# Altium schematic sheet Digital_Output.SchDoc
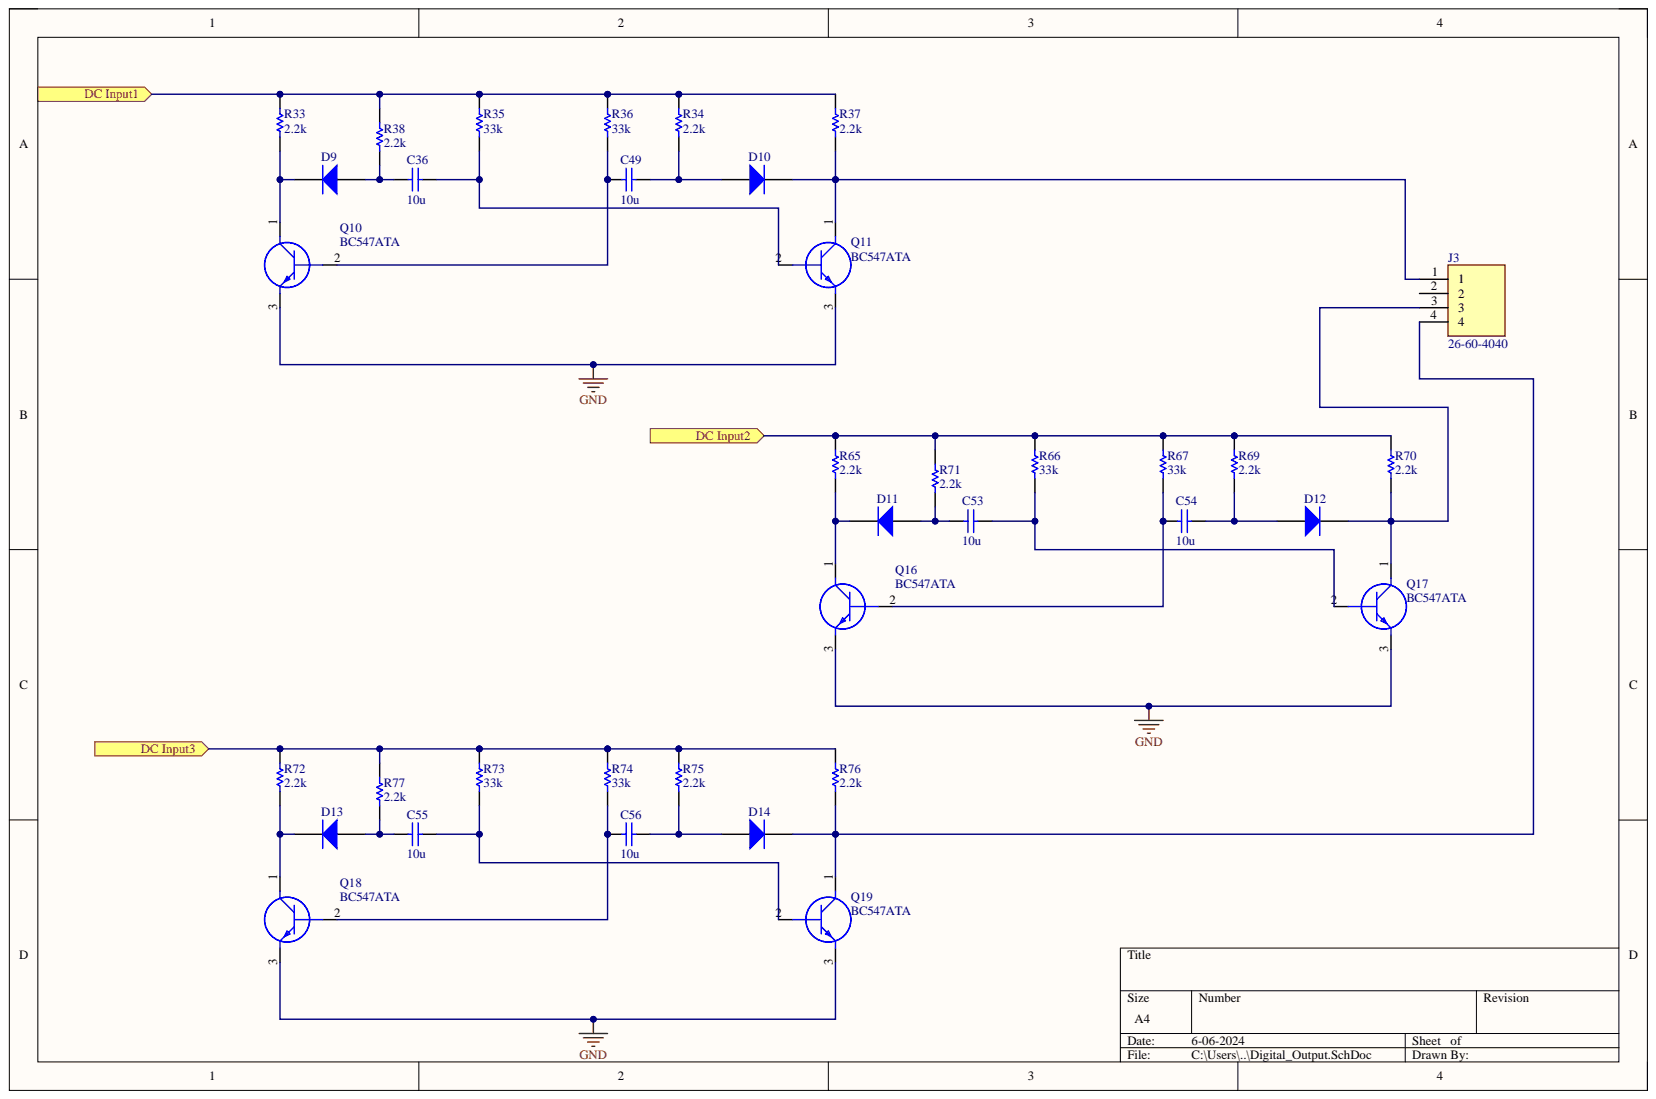
[diagram p0: Digital_Output.SchDoc]

## Parts
C36  value 10u  (hidden comment: Capacitor)
C49  value 10u  (hidden comment: Capacitor)
C53  value 10u  (hidden comment: Capacitor)
C54  value 10u  (hidden comment: Capacitor)
C55  value 10u  (hidden comment: Capacitor)
C56  value 10u  (hidden comment: Capacitor)
D9  Diode  (value not drawn)
D10  Diode  (value not drawn)
D11  Diode  (value not drawn)
D12  Diode  (value not drawn)
D13  Diode  (value not drawn)
D14  Diode  (value not drawn)
J3  26-60-4040  [SHDR4W155P0X396_1X4_1570X1001X1536P]
Q10  BC547ATA  [TO-92_(TO-226)]
Q11  BC547ATA  [TO-92_(TO-226)]
Q16  BC547ATA  [TO-92_(TO-226)]
Q17  BC547ATA  [TO-92_(TO-226)]
Q18  BC547ATA  [TO-92_(TO-226)]
Q19  BC547ATA  [TO-92_(TO-226)]
R33  value 2.2k  (hidden comment: Resistor)
R34  value 2.2k  (hidden comment: Resistor)
R35  value 33k  (hidden comment: Resistor)
R36  value 33k  (hidden comment: Resistor)
R37  value 2.2k  (hidden comment: Resistor)
R38  value 2.2k  (hidden comment: Resistor)
R65  value 2.2k  (hidden comment: Resistor)
R66  value 33k  (hidden comment: Resistor)
R67  value 33k  (hidden comment: Resistor)
R69  value 2.2k  (hidden comment: Resistor)
R70  value 2.2k  (hidden comment: Resistor)
R71  value 2.2k  (hidden comment: Resistor)
R72  value 2.2k  (hidden comment: Resistor)
R73  value 33k  (hidden comment: Resistor)
R74  value 33k  (hidden comment: Resistor)
R75  value 2.2k  (hidden comment: Resistor)
R76  value 2.2k  (hidden comment: Resistor)
R77  value 2.2k  (hidden comment: Resistor)

## Ports
DC Input1, DC Input2, DC Input3

## Nets
GND: Q10.3 Q11.3 Q16.3 Q17.3 Q18.3 Q19.3
DC Input1: R33.2 R34.2 R35.2 R36.2 R37.2 R38.2 ; port DC Input1
DC Input2: R65.2 R66.2 R67.2 R69.2 R70.2 R71.2 ; port DC Input2
DC Input3: R72.2 R73.2 R74.2 R75.2 R76.2 R77.2 ; port DC Input3
NetC36_1: C36.1 D9.1 R38.1
NetC36_2: C36.2 Q11.2 R35.1
NetC49_1: C49.1 Q10.2 R36.1
NetC49_2: C49.2 D10.1 R34.1
NetC53_1: C53.1 D11.1 R71.1
NetC53_2: C53.2 Q17.2 R66.1
NetC54_1: C54.1 Q16.2 R67.1
NetC54_2: C54.2 D12.1 R69.1
NetC55_1: C55.1 D13.1 R77.1
NetC55_2: C55.2 Q19.2 R73.1
NetC56_1: C56.1 Q18.2 R74.1
NetC56_2: C56.2 D14.1 R75.1
NetD9_2: D9.2 Q10.1 R33.1
NetD10_2: D10.2 J3.1 Q11.1 R37.1
NetD11_2: D11.2 Q16.1 R65.1
NetD12_2: D12.2 J3.3 Q17.1 R70.1
NetD13_2: D13.2 Q18.1 R72.1
NetD14_2: D14.2 J3.4 Q19.1 R76.1

Not connected: J3 2

## Library data of the parts
C36 C49 C53-C56 = Capacitor: SimulationGenericComponent: True; Component Kind: Standard; Description: Capacitor; Library Name: Simulation Generic Components.IntLib; Simulation: C_Capacitor_Default
D9-D14 = Diode: AF: 1; BV: 0; BV_MAX: 1e99; EG: 1.11; FC: 0.5; IBV: 0.001; IS: 1e-14; MJSW: 0.33; NTUN: 30; SimulationGenericComponent: True; TNOM: 27; XTI: 3; CJO: 0; CJP: 0; Component Kind: Standard; CTA: 0; CTP: 0; Description: Diode; FCS: 0.5; FV_MAX: 1e99; IKF: 0; IKR: 0; ISR: 1e-14; JSW: 0; JTUN: 0; JTUNSW: 0; KEG: 1; KF: 0; LEVEL: 1; Library Name: Simulation Generic Components.IntLib; N: 1; NBV: 1; NR: 1; NS: 1; PHP: 1; RS: 0; Simulation: diode; TCV: 0; TLEV: 0; TLEVC: 0; TM1: 0; TM2: 0; TPB: 0; TPHP: 0; TRS: 0; TRS2: 0; TT: 0; TTT1: 0; TTT2: 0; VJ: 1; XTITUN: 3
J3 = 26-60-4040: Connector; Manufacturer_Name: Molex; Component Kind: Standard; Datasheet Link: http://www.molex.com/pdm_docs/sd/026604040_sd.pdf; Height: 15.36mm; Manufacturer_Part_Number: 26-60-4040; Mouser Part Number: 538-26-60-4040; Mouser Price/Stock: https://www.mouser.co.uk/ProductDetail/Molex/26-60-4040?qs=tRPrwvvr%2FuiQpDQcO3P3Lw%3D%3D
Q10 Q11 Q16-Q19 = BC547ATA: Transistor BJT NPN; Manufacturer_Name: onsemi; Arrow Part Number: BC547ATA; Arrow Price/Stock: https://www.arrow.com/en/products/bc547ata/on-semiconductor?region=europe; Component Kind: Standard; Datasheet Link: https://www.onsemi.com/pub/Collateral/BC550-D.pdf; Manufacturer_Part_Number: BC547ATA; Mouser Part Number: 512-BC547ATA; Mouser Price/Stock: https://www.mouser.co.uk/ProductDetail/onsemi-Fairchild/BC547ATA?qs=ljbEvF4DwOMRdLfuzO8N4w%3D%3D; Simulation: NPN_Mod_Gummel_Poon
R33-R38 R65-R67 R69-R77 = Resistor: SimulationGenericComponent: True; TC1: 0; Component Kind: Standard; Description: Resistor; Library Name: Simulation Generic Components.IntLib; Simulation: R_Resistor_Default; TC2: 0
